# Altium schematic sheet LoRa_NiceRF.SchDoc
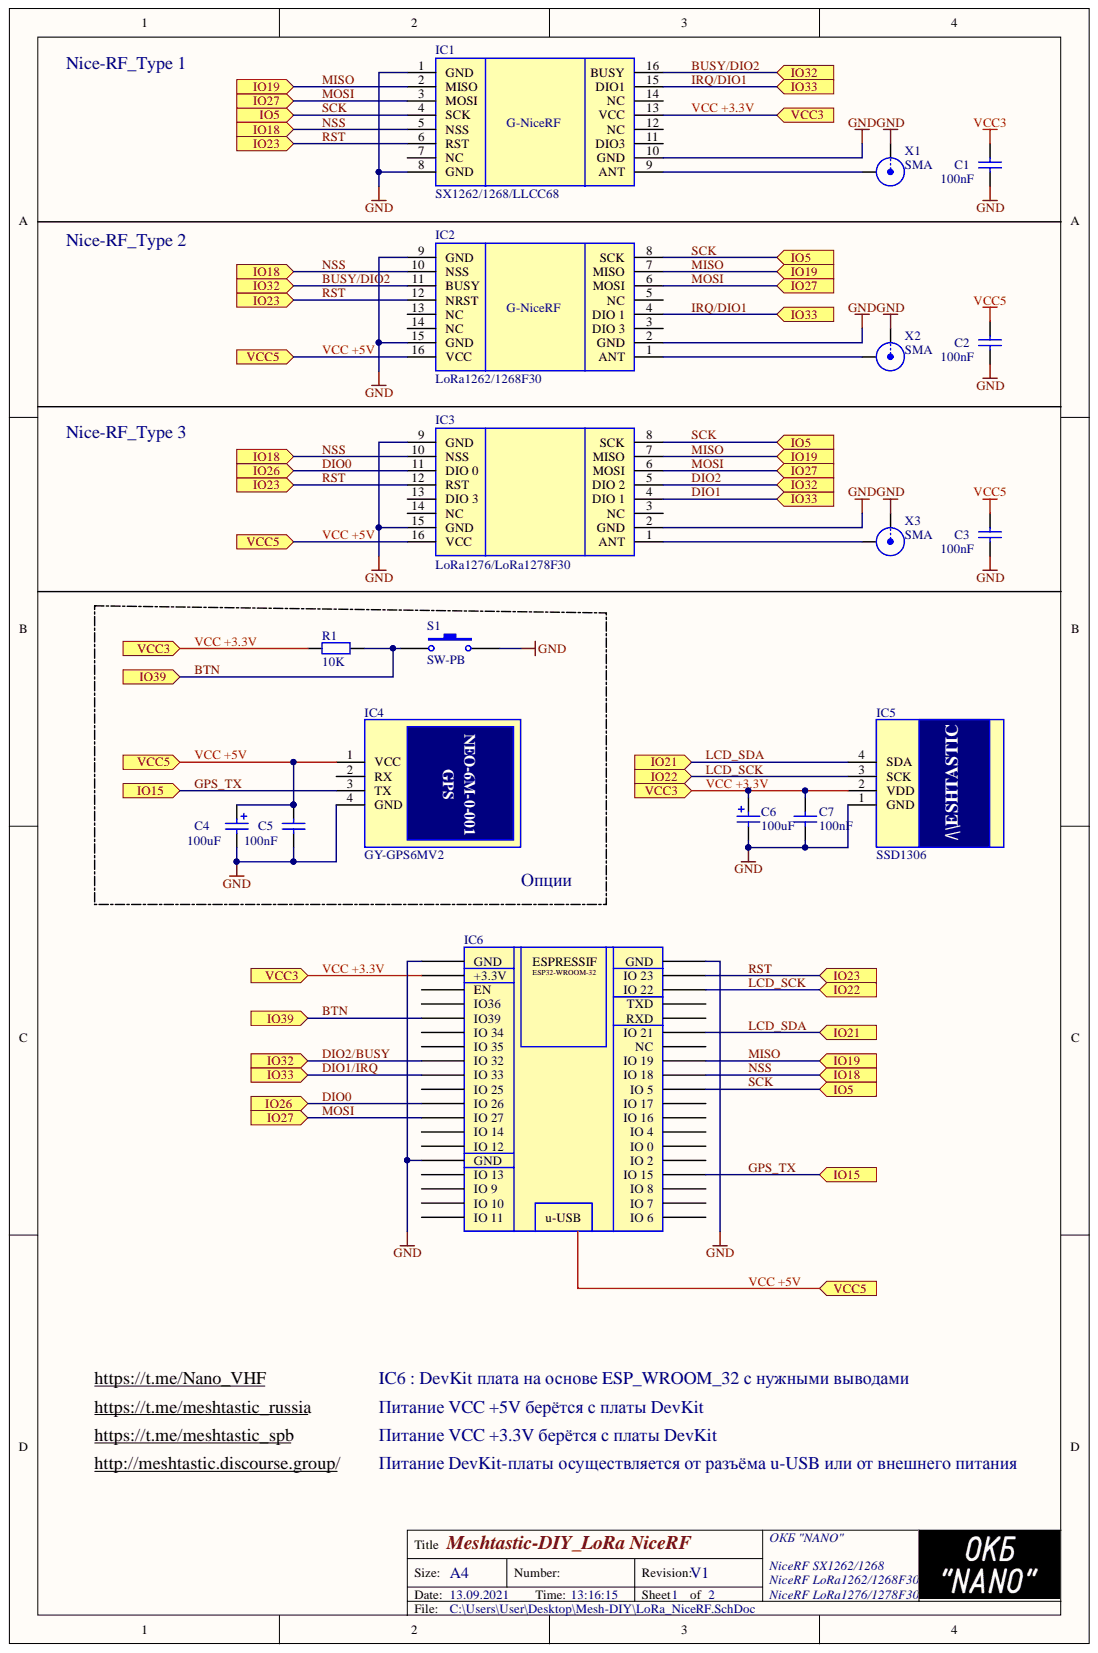
[diagram p0: LoRa_NiceRF.SchDoc]

## Parts
C1  value 100nF  [RAD-0.3]  (hidden comment: Cap)
C2  value 100nF  [RAD-0.3]  (hidden comment: Cap)
C3  value 100nF  [RAD-0.3]  (hidden comment: Cap)
C4  value 100uF  [POLAR0.8]  (hidden comment: Cap Pol2)
C5  value 100nF  [RAD-0.3]  (hidden comment: Cap)
C6  value 100uF  [POLAR0.8]  (hidden comment: Cap Pol2)
C7  value 100nF  [RAD-0.3]  (hidden comment: Cap)
IC1  SX1262/1268/LLCC68  [RFM98W433S2]
IC2  LoRa1262/1268F30  [RFM98W433S2]
IC3  LoRa1276/LoRa1278F30  [RFM98W433S2]
IC4  GY-GPS6MV2  [RFM98W433S2]
IC5  SSD1306  [RFM98W433S2]
IC6  ESP_wroom_32  [ESP32S]  (value not drawn)
R1  10k  [AXIAL-0.4]
S1  SW-PB  [SPST-2]
X1  SMA  [BNC_RA CON]
X2  SMA  [BNC_RA CON]
X3  SMA  [BNC_RA CON]

## Ports
IO5, IO15, IO18, IO19, IO21, IO22, IO23, IO26, IO27, IO32, IO33, IO39, VCC3, VCC5

## Nets
GND: C1.1 C2.1 C3.1 C4.2 C5.1 C6.2 C7.1 IC1.1(GND) IC1.8(GND) IC1.10(GND) IC2.2(GND) IC2.9(GND) IC2.15(GND) IC3.2(GND) IC3.9(GND) IC3.15(GND) IC4.4(GND) IC5.1(GND) IC6.14(GND) IC6.19(GND) IC6.38(GND) S1.1 X1.1 X2.1 X3.1
VCC3: C1.2
VCC5: C2.2 C3.2
BTN: IC6.4(IO39) R1.2 S1.2 ; port IO39
BUSY/DIO2: IC1.16(BUSY) IC2.11(BUSY) IC3.5(DIO_2) IC6.7(IO_32) ; port IO32
DIO0: IC3.11(DIO_0) IC6.10(IO_26) ; port IO26
DIO1: IC1.15(DIO1) IC2.4(DIO_1) IC3.4(DIO_1) IC6.8(IO_33) ; port IO33
GPS_TX: IC4.3(TX) IC6.23(IO_15) ; port IO15
LCD_SCK: IC5.3(SCK) IC6.36(IO_22) ; port IO22
LCD_SDA: IC5.4(SDA) IC6.33(IO_21) ; port IO21
MISO: IC1.2(MISO) IC2.7(MISO) IC3.7(MISO) IC6.31(IO_19) ; port IO19
MOSI: IC1.3(MOSI) IC2.6(MOSI) IC3.6(MOSI) IC6.11(IO_27) ; port IO27
NSS: IC1.5(NSS) IC2.10(NSS) IC3.10(NSS) IC6.30(IO_18) ; port IO18
RST: IC1.6(RST) IC2.12(NRST) IC3.12(RST) IC6.37(IO_23) ; port IO23
SCK: IC1.4(SCK) IC2.8(SCK) IC3.8(SCK) IC6.29(IO_5) ; port IO5
VCC +3.3V: C6.1 C7.2 IC1.13(VCC) IC5.2(VDD) IC6.1(+3.3V) R1.1 ; port VCC3
VCC +5V: C4.1 C5.2 IC2.16(VCC) IC3.16(VCC) IC4.1(VCC) ; port VCC5
NetIC1_9: IC1.9(ANT) X1.2
NetIC2_1: IC2.1(ANT) X2.2
NetIC3_1: IC3.1(ANT) X3.2

Not connected: IC1 7(NC) 11(DIO3) 12(NC) 14(NC); IC2 3(DIO_3) 5(NC) 13(NC) 14(NC); IC3 3(NC) 13(DIO_3) 14(NC); IC4 2(RX); IC6 2(EN) 3(IO36) 5(IO_34) 6(IO_35) 9(IO_25) 12(IO_14) 13(IO_12) 15(IO_13) 16(IO_9) 17(IO_10) 18(IO_11) 20(IO_6) 21(IO_7) 22(IO_8) 24(IO_2) 25(IO_0) 26(IO_4) 27(IO_16) 28(IO_17) 32(NC) 34(RXD) 35(TXD)

## Library data of the parts
C1-C3 C5 C7 = Cap: Capacitor; Component Kind: Standard; LatestRevisionDate: 17-Jul-2002; LatestRevisionNote: Re-released for DXP Platform.; Library Name: Miscellaneous Devices.IntLib; PackageDescription: Radial Cap, Thru-Hole; 2 Leads; 0.3 in Pin Spacing; PackageReference: RAD-0.3; Published: 8-Jun-2000; Signal Integrity: Cap; Simulation: CAP; Publisher: Altium Limited
C4 C6 = Cap Pol2: Polarized Capacitor (Axial); Component Kind: Standard; LatestRevisionDate: 17-Jul-2002; LatestRevisionNote: Re-released for DXP Platform.; Library Name: Miscellaneous Devices.IntLib; PackageDescription: Polarized Capacitor; 2 Leads; PackageReference: POLAR0.8; Published: 8-Jun-2000; Signal Integrity: Cap Pol; Simulation: CAP; Publisher: Altium Limited
IC1 = SX1262: Integrated Circuit; Manufacturer_Name: RF SOLUTIONS; Manufacturer_Part_Number: RFM98W-433S2; Component Kind: Standard; Datasheet Link: http://www.hoperf.com/upload/rf/RFM95_96_97_98W.pdf; Height: 1.8mm; Library Name: ESP32S.SchLib; Mouser Part Number: 223-RFM98W-433S2; Mouser Price/Stock: https://www.mouser.co.uk/ProductDetail/RF-Solutions/RFM98W-433S2?qs=OlC7AqGiEDlY9molZxTrLQ%3D%3D
IC2 = SX1262_315: G-NiceRF; Manufacturer_Name: RF SOLUTIONS; Manufacturer_Part_Number: RFM98W-433S2; Component Kind: Standard; Datasheet Link: http://www.hoperf.com/upload/rf/RFM95_96_97_98W.pdf; Height: 1.8mm; Library Name: ESP32S.SchLib; Mouser Part Number: 223-RFM98W-433S2; Mouser Price/Stock: https://www.mouser.co.uk/ProductDetail/RF-Solutions/RFM98W-433S2?qs=OlC7AqGiEDlY9molZxTrLQ%3D%3D
IC3 = LoRa1276F30: Manufacturer_Name: RF SOLUTIONS; Manufacturer_Part_Number: RFM98W-433S2; Component Kind: Standard; Datasheet Link: http://www.hoperf.com/upload/rf/RFM95_96_97_98W.pdf; Description: LoRa1276F30; Height: 1.8mm; Library Name: LoRa.SCHLIB; Mouser Part Number: 223-RFM98W-433S2; Mouser Price/Stock: https://www.mouser.co.uk/ProductDetail/RF-Solutions/RFM98W-433S2?qs=OlC7AqGiEDlY9molZxTrLQ%3D%3D
IC4 = GPS: Manufacturer_Name: RF SOLUTIONS; Manufacturer_Part_Number: RFM98W-433S2; Component Kind: Standard; Datasheet Link: http://www.hoperf.com/upload/rf/RFM95_96_97_98W.pdf; Description: GY-GPS6MV2; Height: 1.8mm; Library Name: ESP32S.SchLib; Mouser Part Number: 223-RFM98W-433S2; Mouser Price/Stock: https://www.mouser.co.uk/ProductDetail/RF-Solutions/RFM98W-433S2?qs=OlC7AqGiEDlY9molZxTrLQ%3D%3D
IC5 = SSD1306: OLED LCD; Manufacturer_Name: RF SOLUTIONS; Manufacturer_Part_Number: RFM98W-433S2; Component Kind: Standard; Datasheet Link: http://www.hoperf.com/upload/rf/RFM95_96_97_98W.pdf; Height: 1.8mm; Library Name: ESP32S.SchLib; Mouser Part Number: 223-RFM98W-433S2; Mouser Price/Stock: https://www.mouser.co.uk/ProductDetail/RF-Solutions/RFM98W-433S2?qs=OlC7AqGiEDlY9molZxTrLQ%3D%3D
IC6 = ESP_wroom_32: Esp-32S; Component Kind: Standard; Datasheet: https://espressif.com/sites/default/files/documentation/esp_wroom_32_datasheet_en.pdf; Library Name: ESP32S.SchLib
R1 = Res2: Resistor; Component Kind: Standard; LatestRevisionDate: 17-Jul-2002; LatestRevisionNote: Re-released for DXP Platform.; Library Name: Miscellaneous Devices.IntLib; PackageDescription: Axial Device, Thru-Hole; 2 Leads; 0.4 in Pin Spacing; PackageReference: AXIAL-0.4; Published: 8-Jun-2000; Signal Integrity: Res; Simulation: RESISTOR; Value: 10K; Publisher: Altium Limited
S1 = SW-PB: Switch; Component Kind: Standard; LatestRevisionDate: 17-Jul-2002; LatestRevisionNote: Re-released for DXP Platform.; Library Name: Miscellaneous Devices.IntLib; PackageDescription: Switch; 2 Leads; PackageReference: SPST-2; Published: 24-Mar-1999; Publisher: Altium Limited
X1-X3 = BNC: BNC Elbow Connector; Component Kind: Standard; LatestRevisionDate: 17-Jul-2002; LatestRevisionNote: Re-released for DXP Platform.; Library Name: Miscellaneous Connectors.IntLib; Signal Integrity: Connector; Publisher: Altium Limited
